# Altium schematic sheet Connectors_JTAG.SchDoc(Connectors_JTAG)
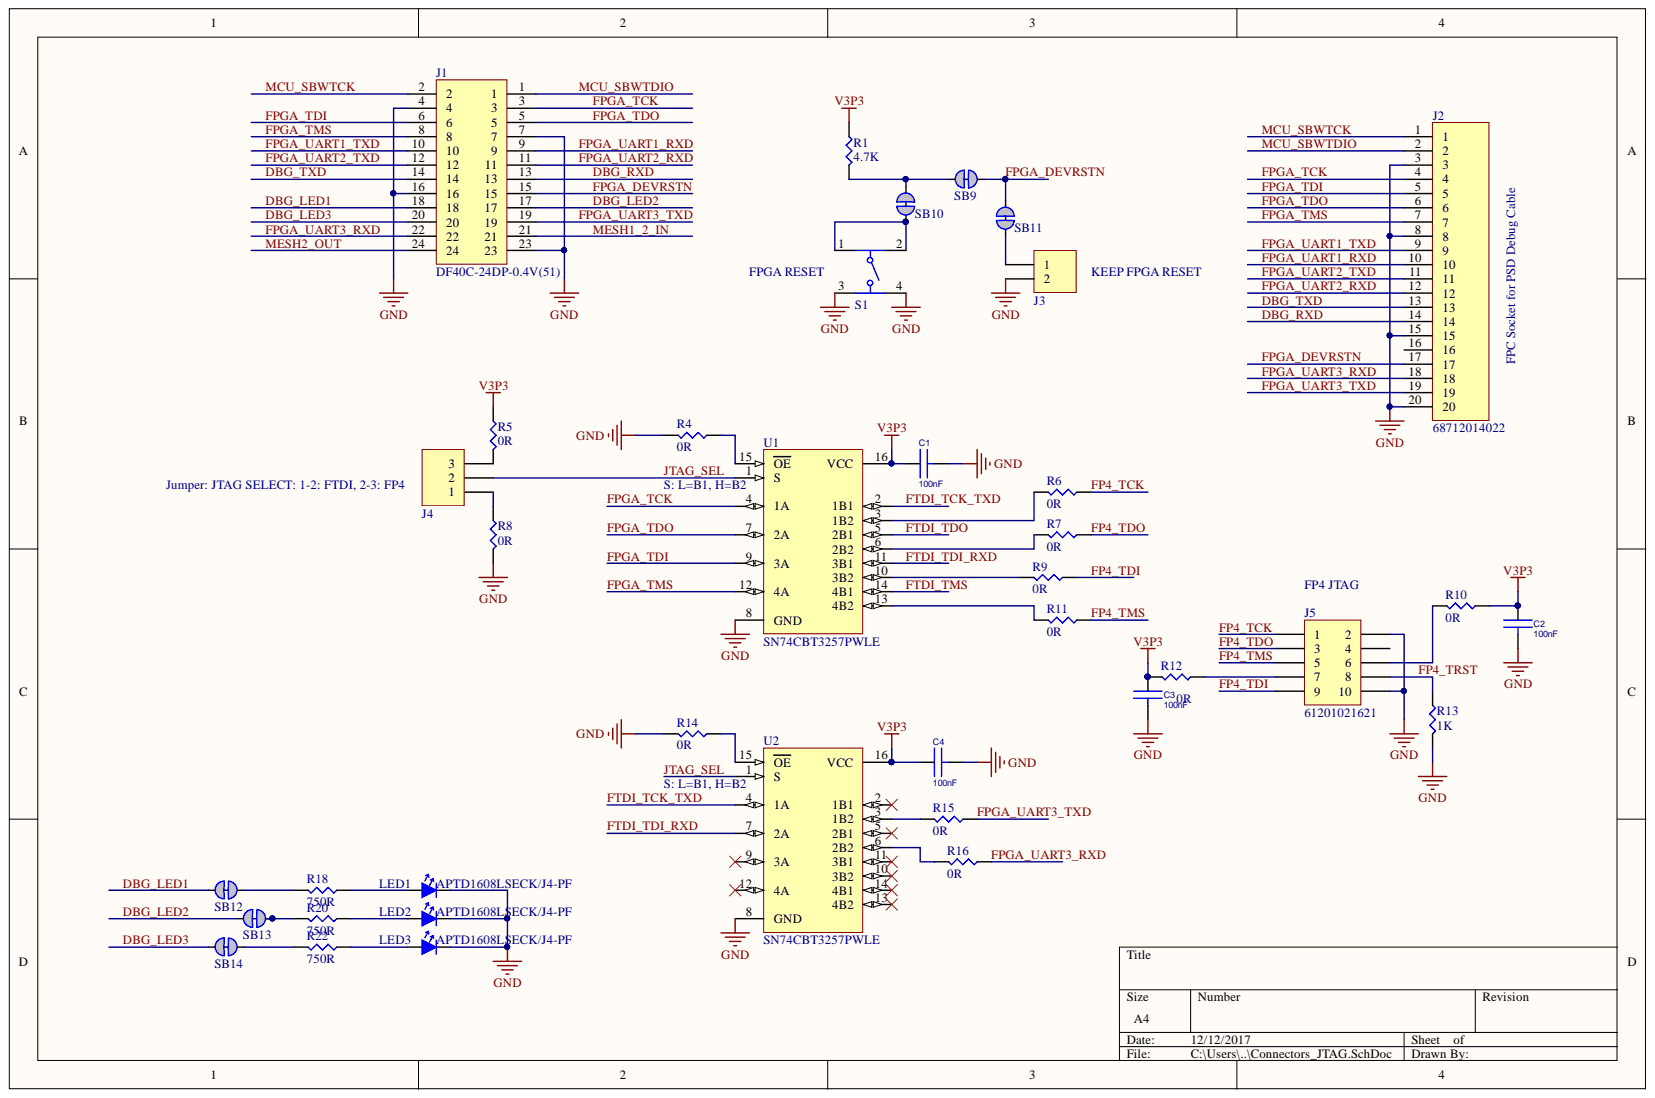
[diagram p0: Connectors_JTAG.SchDoc]

## Parts
C1  100nF  [0603C]
C2  100nF  [0603C]
C3  100nF  [0603C]
C4  100nF  [0603C]
J1  DF40C-24DP-0.4V(51)  [DF40C-24DP-0.4V(51)]
J2  68712014022  [ZIF_0.5MM_20P]
J3  61300211121  [61300211121]  (value not drawn)
J4  61300311121  [61300311121]  (value not drawn)
J5  61201021621  [61201021621]
LED1  APTD1608LSECK/J4-PF  [LED0603]
LED2  APTD1608LSECK/J4-PF  [LED0603]
LED3  APTD1608LSECK/J4-PF  [LED0603]
R1  4.7K  [R0603]
R4  0R  [R0603]
R5  0R  [R0603]
R6  0R  [R0603]
R7  0R  [R0603]
R8  0R  [R0603]
R9  0R  [R0603]
R10  0R  [R0603]
R11  0R  [R0603]
R12  0R  [R0603]
R13  1K  [R0603]
R14  0R  [R0603]
R15  0R  [R0603]
R16  0R  [R0603]
R18  750R  [R0603]
R20  750R  [R0603]
R22  750R  [R0603]
S1  430773034825  [4307x30348xx_6x6x3.4]  (value not drawn)
SB9  Solderbridge  [Solderbridge_Shorted]  (value not drawn)
SB10  Solderbridge  [Solderbridge_Shorted]  (value not drawn)
SB11  Solderbridge  [Solderbridge_Shorted]  (value not drawn)
SB12  Solderbridge  [Solderbridge_Shorted]  (value not drawn)
SB13  Solderbridge  [Solderbridge_Shorted]  (value not drawn)
SB14  Solderbridge  [Solderbridge_Shorted]  (value not drawn)
U1  SN74CBT3257PWLE  [PW016_N]
U2  SN74CBT3257PWLE  [PW016_N]

## Nets
GND: C1.2 C2.2 C3.2 C4.2 J1.4 J1.7 J1.16 J1.23 J2.3 J2.8 J2.15 J2.20 J3.2 J5.2 J5.10 LED1.1 LED2.1 LED3.1 R4.2 R8.2 R13.2 R14.2 S1.3 S1.4 U1.8(GND) U2.8(GND)
V3P3: C1.1 C2.1 C3.1 C4.1 R1.1 R5.1 R10.1 R12.2 U1.16(VCC) U2.16(VCC)
DBG_LED1: J1.18 SB12.2
DBG_LED2: J1.17 SB13.2
DBG_LED3: J1.20 SB14.2
DBG_RXD: J1.13 J2.14
DBG_TXD: J1.14 J2.13
FP4_TCK: J5.1 R6.1
FP4_TDI: J5.9 R9.1
FP4_TDO: J5.3 R7.1
FP4_TMS: J5.5 R11.1
FP4_TRST: J5.8 R13.1
FPGA_DEVRSTN: J1.15 J2.17 SB9.1 SB11.1
FPGA_TCK: J1.3 J2.4 U1.4(1A)
FPGA_TDI: J1.6 J2.5 U1.9(3A)
FPGA_TDO: J1.5 J2.6 U1.7(2A)
FPGA_TMS: J1.8 J2.7 U1.12(4A)
FPGA_UART1_RXD: J1.9 J2.10
FPGA_UART1_TXD: J1.10 J2.9
FPGA_UART2_RXD: J1.11 J2.12
FPGA_UART2_TXD: J1.12 J2.11
FPGA_UART3_RXD: J1.22 J2.18 R16.1
FPGA_UART3_TXD: J1.19 J2.19 R15.1
FTDI_TCK_TXD: U1.2(1B1) U2.4(1A)
FTDI_TDI_RXD: U1.11(3B1) U2.7(2A)
FTDI_TDO: U1.5(2B1)
FTDI_TMS: U1.14(4B1)
JTAG_SEL: J4.2 U1.1(S) U2.1(S)
MCU_SBWTCK: J1.2 J2.1
MCU_SBWTDIO: J1.1 J2.2
MESH1_2_IN: J1.21
MESH2_OUT: J1.24
NetJ3_1: J3.1 SB11.2
NetJ4_1: J4.1 R8.1
NetJ4_3: J4.3 R5.2
NetJ5_6: J5.6 R10.2
NetJ5_7: J5.7 R12.1
NetLED1_2: LED1.2 R18.1
NetLED2_2: LED2.2 R20.1
NetLED3_2: LED3.2 R22.1
NetR1_2: R1.2 SB9.2 SB10.1
NetR4_1: R4.1 U1.15(OE)
NetR6_2: R6.2 U1.3(1B2)
NetR7_2: R7.2 U1.6(2B2)
NetR9_2: R9.2 U1.10(3B2)
NetR11_2: R11.2 U1.13(4B2)
NetR14_1: R14.1 U2.15(OE)
NetR15_2: R15.2 U2.3(1B2)
NetR16_2: R16.2 U2.6(2B2)
NetR18_2: R18.2 SB12.1
NetR20_2: R20.2 SB13.1
NetR22_2: R22.2 SB14.1
NetS1_1: S1.1 S1.2 SB10.2

Not connected: J2 16; J5 4; U2 2(1B1) 5(2B1) 9(3A) 10(3B2) 11(3B1) 12(4A) 13(4B2) 14(4B1)

## Library data of the parts
C1-C4 = 100nF/0603: Description 1: CAP CER 0.1UF 25V 10% X7R 0603; Supplier Part Number 1: 311-1341-6-ND; Supplier 1: Digi-Key; Library Name: Control_Board.SchLib; Component Kind: Standard
J1 = DF40C-24DP-0.4V(51): Description 1: CONN HDR 24POS 0.4MM SMD GOLD; Supplier Part Number 1: H11620CT-ND; Supplier 1: Digi-Key; Component Kind: Standard; Library Name: AMOLED.SchLib
J2 = 68712014022: Description 1: CONN FPC TOP 20POS 0.50MM R/A; Supplier Part Number 1: 732-2023-6-ND; Supplier 1: Digi-Key; Component Kind: Standard; Library Name: AMOLED.SchLib
J3 = 61300211121: WR-PHD 2.54 mm THT Pin Header, 2p; Working Voltage: 250 VAC; Withstanding Voltage: 500 VAC; Rated Current: 3 A; Pitch: 2.54 mm; Pin Number: 2; Published Date: Aug 2017; Part Number: 61300211121; Library Name: Altium_WR-PHD_PIN_HEADER_2_54 (rev17a).IntLib; ComponentLink2URL: http://katalog.we-online.de/em/datasheet/6130xx11121.pdf; ComponentLink2Description: Datasheet; ComponentLink1URL: http://www.we-online.de; ComponentLink1Description: Manufacturer; Component Kind: Standard; Publisher: Wurth Elektronik
J4 = 61300311121: WR-PHD 2.54 mm THT Pin Header, 3p; Working Voltage: 250 VAC; Withstanding Voltage: 500 VAC; Rated Current: 3 A; Pitch: 2.54 mm; Pin Number: 3; Published Date: Aug 2017; Part Number: 61300311121; Library Name: Altium_WR-PHD_PIN_HEADER_2_54 (rev17a).IntLib; ComponentLink2URL: http://katalog.we-online.de/em/datasheet/6130xx11121.pdf; ComponentLink2Description: Datasheet; ComponentLink1URL: http://www.we-online.de; ComponentLink1Description: Manufacturer; Component Kind: Standard; Publisher: Wurth Elektronik
J5 = 61201021621: WR-BHD 2.54mm Male Box Header; Manufacturer: Wurth Elektronik; NoPins: 10; ComponentLink2 Description: Datasheet; ComponentLink1 Description: Manufacturer; partNo: 61201021621; Library Name: ALTIUM-BHD_2_54_MALE_BOX_HEADER_6120XX21621.IntLib; Created Date: March 2016; ComponentLink2URL: http://katalog.we-online.de/em/datasheet/6120xx21621.pdf; ComponentLink1URL: http://www.we-online.com; Component Kind: Standard; Created by: Baojun Chen
LED1-LED3 = LED_ORGN_0603_KB: Description 1: LED ORANGE CLEAR 0603 SMD; Supplier Part Number 1: 754-1946-1-ND; Supplier 1: Digi-Key; Library Name: Control_Board.SchLib; Component Kind: Standard
R1 R4-R16 R18 R20 R22 = 1K/1%/0603: Description 1: Thick Film Resistors - SMD 1K OHM 1%; Supplier Part Number 1: 311-1.00KHRCT-ND; Supplier 1: Digi-Key; Library Name: Control_Board.SchLib; Component Kind: Standard
S1 = 430773034825: WS-TASV 6x6mm J-Hook Hermetic Tact Switch, SMD version; Operation Force: 250g; Size: 6x6x3.4 mm; Published Date: June 2017; Part Number: 430773034825; Life Cycle: 500000 cycles; Library Name: Altium_WS-TASV (rev17a).IntLib; ComponentLink2URL: http://katalog.we-online.de/em/datasheet/430773034825.pdf; ComponentLink2Description: Datasheet; ComponentLink1URL: http://www.we-online.de; ComponentLink1Description: Manufacturer; Component Kind: Standard; Publisher: Wurth Elektronik
SB9-SB14 = SOLDERBRIDGE_SHORTED: Shorted Solderbridge; Part Number: Solderbridge Shorted; Artikkelnr Simpro: N.A.; Value: Solderbridge; Published: 2012-08-07; Pin Count: 2; Library Name: pca10040.IntLib; LatestRevisionDate: 2012-08-07; Footprint Doc: Solderbridge_Shorted; Component Kind: Net Tie; Artikkelnr Noca: N.A.; Publisher: Nordic Semiconductor
U1 U2 = SN74CBT3257PWLE: 4-Bit 1-of-2 FET Multiplexer/Demultiplexer; Published: 8-Jun-2000; PCB3D: PW016; PackageVersion: Feb-1999; PackageReference: PW016; PackageDescription: 16-Pin Thin Small Outline Package 0.65 mm Pitch; Library Name: TI Logic Multiplexer.IntLib; LatestRevisionNote: IPC-7351 Footprint Added.; LatestRevisionDate: 29-Mar-2007; DatasheetVersion: May-1998; ComponentLink2URL: http://www-s.ti.com/sc/ds/sn74cbt3257.pdf; ComponentLink2Description: Datasheet; ComponentLink1URL: http://www.ti.com/; ComponentLink1Description: Manufacturer Link; Component Kind: Standard; Publisher: Altium Limited
